# Altium schematic sheet INTERFACE.SchDoc(INTERFACE)
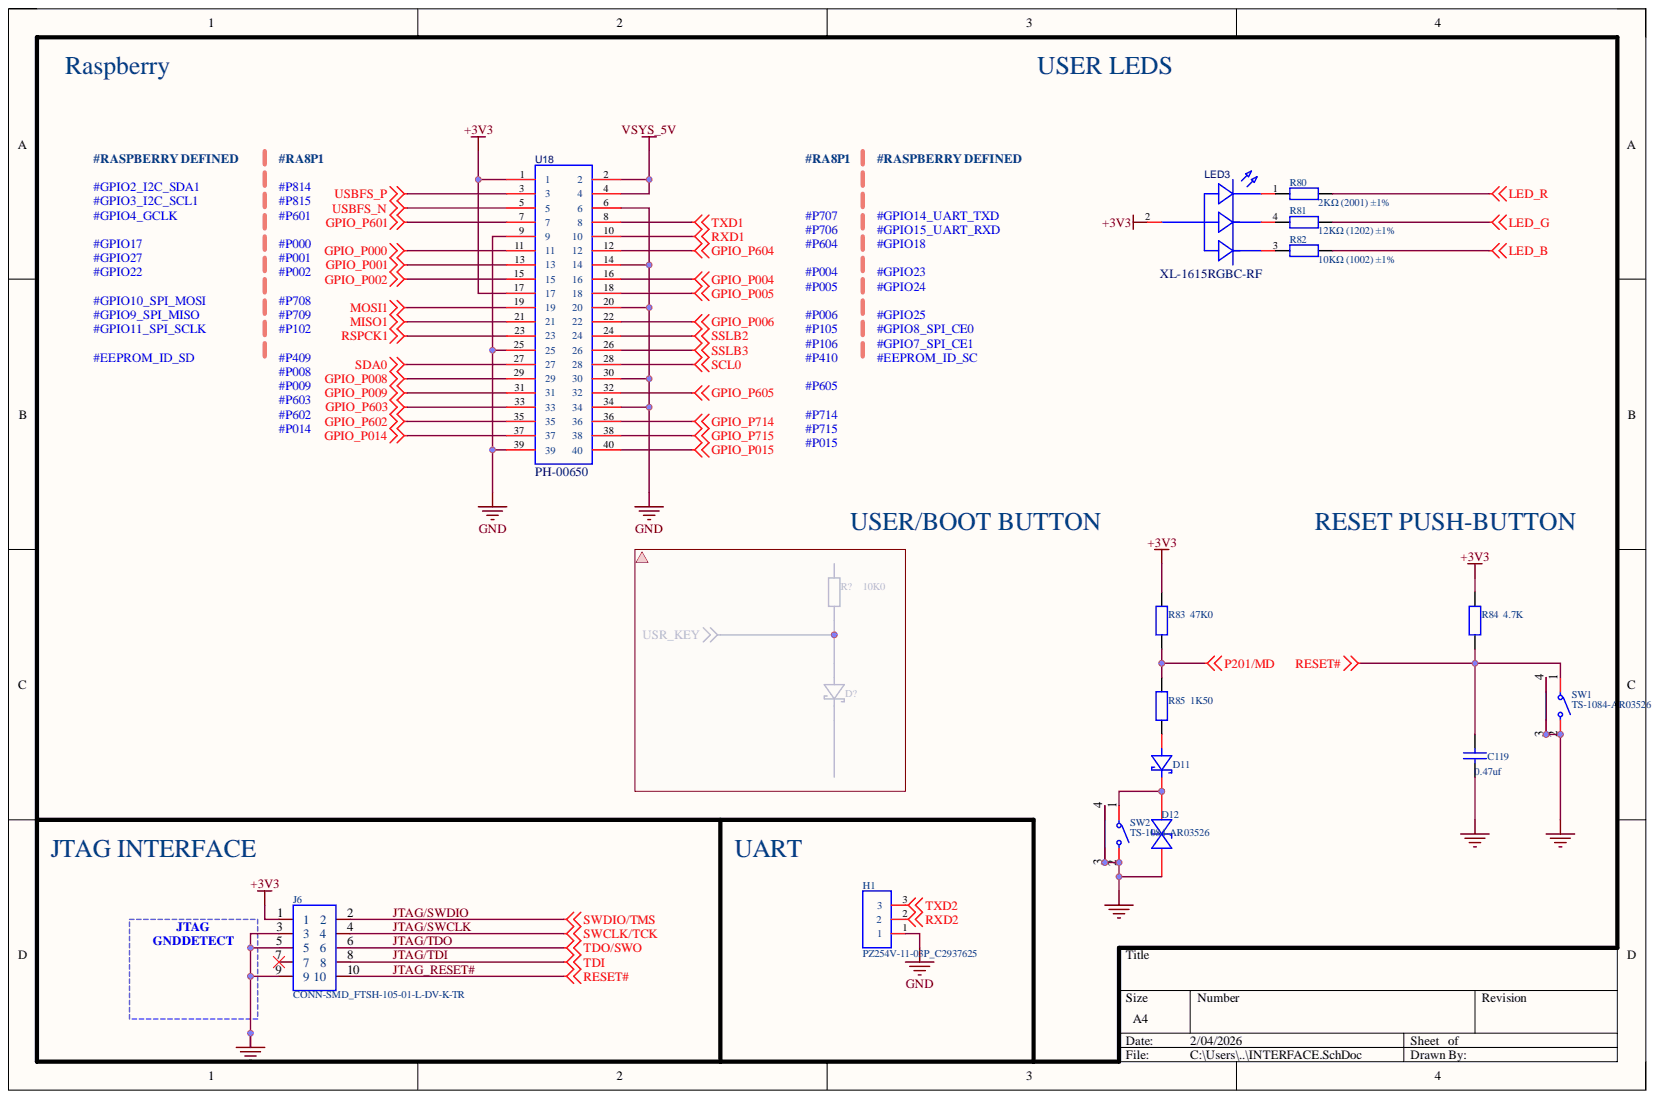
[diagram p0: INTERFACE.SchDoc]

## Parts
C119  0.47uf  [C0402]
D11  1N4148WS  [SOD-323_L1.7-W1.3-LS2.5-RD-1]  (value not drawn)
D12  TPESD0201G05V  [D0201-BI]  (value not drawn)
H1  PZ254V-11-03P_C2937625  [HDR-TH_3P-P2.54-V-M_PZ254V-11-03P]
J6  CONN-SMD_FTSH-105-01-L-DV-K-TR  [CONN-SMD_FTSH-105-01-L-DV-K-TR]
LED3  XL-1615RGBC-RF  [LED-ARRAY-SMD_4P-L1.6-W1.5-BR_XL-1615RGBC-RF]
R80  2KΩ (2001) ±1%  [R0402]
R81  12KΩ (1202) ±1%  [R0402]
R82  10KΩ (1002) ±1%  [R0402]
R83  47K0  [R0402]
R84  4.7K  [R0402]
R85  1K50  [R0402]
SW1  TS-1084-AR03526  [SW-SMD_TS-1084-AR03526]
SW2  TS-1084-AR03526  [SW-SMD_TS-1084-AR03526]
U18  PH-00650  [HDR-SMD_40P-P2.54-V-M-R2-C20-S7.8-EH]

## Nets
+3V3: J6.1 LED3.2 R83.2 R84.2 U18.1 U18.17
GND: C119.1 D12.1 H1.1 J6.3 J6.5 J6.9 SW1.2 SW1.3 SW1.4 SW2.2 SW2.3 SW2.4 U18.6 U18.9 U18.14 U18.20 U18.25 U18.30 U18.34 U18.39
GPIO_P000: U18.11
GPIO_P001: U18.13
GPIO_P002: U18.15
GPIO_P004: U18.16
GPIO_P005: U18.18
GPIO_P006: U18.22
GPIO_P008: U18.29
GPIO_P009: U18.31
GPIO_P014: U18.37
GPIO_P015: U18.40
GPIO_P601: U18.7
GPIO_P602: U18.35
GPIO_P603: U18.33
GPIO_P604: U18.12
GPIO_P605: U18.32
GPIO_P714: U18.36
GPIO_P715: U18.38
LED_B: R82.2
LED_G: R81.2
LED_R: R80.2
MISO1: U18.21
MOSI1: U18.19
P201/MD: R83.1 R85.2
RSPCK1: U18.23
RXD1: U18.10
RXD2: H1.2
SCL0: U18.28
SDA0: U18.27
SSLB2: U18.24
SSLB3: U18.26
TXD1: U18.8
TXD2: H1.3
USBFS_N: U18.5
USBFS_P: U18.3
VSYS_5V: U18.2 U18.4
JTAG/SWCLK: J6.4
JTAG/SWDIO: J6.2
JTAG/TDI: J6.8
JTAG/TDO: J6.6
JTAG_RESET#: C119.2 J6.10 R84.1 SW1.1
NetD11_1: D11.1 D12.2 SW2.1
NetD11_2: D11.2 R85.1
NetLED3_1: LED3.1 R80.1
NetLED3_3: LED3.3 R82.1
NetLED3_4: LED3.4 R81.1

Not connected: J6 7

## Library data of the parts
C119 = CAP-000114-01: Ceramic Chip Capacitor 0402 0.47uF 10V; Manufacturer: Samsung; Manufacturer Part Number 1: CL05A474KP5NNNC; Dialectric: X5R; Notes: ANY EQUIVALENT; Tolerance: 10%; Capacitance: 0.47uF; ClassName: Reset; Component Kind: Standard; Library Name: Capacitors.IntLib; Manufacturer 1: Samsung; Mfg Part Number: CL05A474KP5NNNC; Package: 0402; Supplier 1: Digi-Key; Supplier Part Number 1: 1276-1173-1-ND; Voltage: 10V
D11 = 1N4148WS: 100V,150mA，开关二极管; Manufacturer: 宏嘉诚; Add into BOM: yes; Average Rectified Current (Io): 350mA; Device: SD103AWS_C7420360; Diode Configuration: 独立式; Forward Voltage (Vf@If): 370mV@20mA; Peak forward surge current: 1.5A; Reverse Leakage Current (Ir): 5uA@40V; Reverse Voltage (Vr): 40V; Component Kind: Standard; Convert to PCB: yes; Datasheet: https://atta.szlcsc.com/upload/public/pdf/source/20230828/EB98D42952FEC013AACB2F62A2475546.pdf; JLCPCB Part Class: Extended Part; LCSC Part Name: 电压:40V 电流:350mA; Library Name: ra8p1_v0.2.SCHLIB; Manufacturer Part: 1N4148WS; PCB3D: SOD-323_L1.7-W1.3-LS2.6-RD-1; Supplier: LCSC; Supplier Footprint: SOD-323; Supplier Part: C7420319; Symbol: SD103AWS_C7420360
D12 = TPESD0201G05V: TVS,0201,Vdc=5V，Vc=40V; Manufacturer: TECH PUBLIC(台舟); Add into BOM: yes; Type: ESD; Component Kind: Standard; Convert to PCB: yes; Datasheet: https://atta.szlcsc.com/upload/public/pdf/source/20231208/AB2619EB68EB5FE4BD54B2390A15C9FE.pdf; Device: TPESD0201G05V; JLCPCB Part Class: Extended Part; LCSC Part Name: TPESD0201G05V; Library Name: ra8p1_v0.1.SCHLIB; Manufacturer Part: TPESD0201G05V; PCB3D: D0201_L0.6-W0.3-H0.3; Supplier: LCSC; Supplier Footprint: 0201; Supplier Part: C558457; Symbol: TPESD0201G05V
J6 = HX PZ1.27-2x5P TP: Manufacturer: hanxia(韩下); Add into BOM: yes; Circluar Pins/Square Pins: 方针; Current Rating: 1A; Pin Structure: 2x5P; Pitch: 1.27mm; Color: 黑色; Component Kind: Standard; Contact Material: 黄铜; Contact Plating: 金; Convert to PCB: yes; Datasheet: https://atta.szlcsc.com/upload/public/pdf/source/20240830/6C2540B6182E5928E8E33F1671F88B22.pdf; Device: HX PZ1.27-2x5P TP; Insulation Height: 1mm; JLCPCB Part Class: Extended Part; LCSC Part Name: 2x5P 间距:1.27mm 方针 立贴; Length of End Connection Pin: -; Length of Mating Pin: 4mm; Library Name: RA8P1.SCHLIB; Manufacturer Part: HX PZ1.27-2x5P TP; Mounting Type: 立贴; Number of Pins: 10P; Number of Rows: 双排; Operating Temperature: -40℃~+105℃; PCB3D: HDR-SMD_10P-P1.27-V-M-R2-C5-LS5.5_1; Row Spacing: 1.27mm; Supplementary Features: -; Supplier: LCSC; Supplier Footprint: SMD,P=1.27mm; Supplier Part: C41376037; Symbol: HX PZ1.27-2X5P TP; Voltage Rating (Max): -
R80 = 0402 2KΩ (2001) 1%: 贴片电阻; Component Kind: Standard; ComponentLink1Description: 供应商链接; ComponentLink1URL: http://www.szlcsc.com/product/details_4516.html; Library Name: RES.SchLib; Package: 0402; Supplier: LC; SuppliersPartNumber: C4109
R81 = 0402 12KΩ (1202) 1%: 贴片电阻; Component Kind: Standard; ComponentLink1Description: 供应商链接; ComponentLink1URL: http://www.szlcsc.com/product/details_26495.html; Library Name: RES.SchLib; Package: 0402; Supplier: LC; SuppliersPartNumber: C25752
R82 = 0402 10KΩ (1002) 1%: 贴片电阻，10K; Component Kind: Standard; ComponentLink1Description: 供应商链接; ComponentLink1URL: https://item.szlcsc.com/26487.html?fromZone=l_b__%2522brand%2522&spm=sc.bid.xh1.zy.n&lcsc_vid=FANcVFBQFQMNX1cFFAdZVVZXEgdeAgdWFlQNUVAHT1ExVlNSRFhXVlJQQFlYVzsOAxUeFF5JWA0HFBBIBBsCBBcFWQIBCksEBQAAA0tfTk8GEwkK; Library Name: RA8P1.SCHLIB; Package: 0402; Supplier: LC; SuppliersPartNumber: C25744
R83 = RES-000173-01: Chip Resistor Thick Film 0402 47K0 1% 1/10W; Manufacturer: Panasonic; Manufacturer Part Number 1: ERJ-2RKF4702X; Notes: ANY EQUIVALENT; ClassName: Boot-Mode; Component Kind: Standard; Library Name: Resistors.IntLib; Manufacturer 1: Panasonic; Mfg Part Number: ERJ-2RKF4702X; Package: 0402; Power: 1/10W; Resistance: 47K0; Supplier 1: Digi-Key; Supplier Part Number 1: P47.0KLCT-ND; Tolerance: 1%
R84 = RES-100119-01: Chip Resistor Thick Film 0402 4.7K ohm 1% 1/16W; Legacy Part Number: 150-00000094; Manufacturer: Yageo; Manufacturer Part Number 1: RC0402FR-074K7L; Notes: ANY EQUIVALENT; Resistance: 4K7; ClassName: Reset; Component Kind: Standard; Library Name: Resistors.IntLib; Manufacturer 1: Yageo; Mfg: Yageo; Mfg P/N: RC0402FR-074K7L; Mfg Part Number: RC0402FR-074K7L; Package: 0402; PN: RC0402FR-074K7L; Power: 1/16W; Supplier 1: Future Electronics; Supplier 2: Digi-Key; Supplier 3: Mouser; Supplier 4: Farnell; Supplier Part Number 1: 3795218; Supplier Part Number 2: 311-4.7KLRCT-ND; Supplier Part Number 3: 603-RC0402FR-074K7L; Supplier Part Number 4: 9239316; Tolerance: 1%
R85 = RES-000140-01: Chip Resistor Thick Film 0402 1K50 0.5% 1/16W; Manufacturer: Panasonic; Manufacturer Part Number 1: ERA-2AED152X; Notes: ANY EQUIVALENT; ClassName: Boot-Mode; Component Kind: Standard; Library Name: Resistors.IntLib; Manufacturer 1: Panasonic; Mfg Part Number: ERA-2AED152X; Package: 0402; Power: 1/16W; Resistance: 1K50; Supplier 1: Digi-Key; Supplier Part Number 1: P1.5KDECT-ND; Tolerance: 0.5%
SW1 SW2 = TS-1084-AR03526: 按键侧贴，2.6N; Manufacturer: XUNPU(讯普); Actuator Style: 圆形按钮; Actuator/Cap Color: 白色; Add into BOM: yes; Circuit: 单刀单掷; Contact Current: 50mA; Insulation Resistance: 100MΩ; Mechanical Life: 10万次; Operating Force: 260gf; Pin Style: SMD接片; Switch Height: 3.5mm; Switch Length: 4.5mm; Switch Width: 3.4mm; Voltage Rating (DC): 12V; Component Kind: Standard; Convert to PCB: yes; Datasheet: https://atta.szlcsc.com/upload/public/pdf/source/20200810/C720495_E832ACC0F01765863927299F269536F0.pdf; Device: TS-1084-AR03526; JLCPCB Part Class: Extended Part; LCSC Part Name: 4.5*3.4*3.5mm 卧贴 轻触开关; Library Name: ra8p1_v0.2.SCHLIB; Manufacturer Part: TS-1084-AR03526; Mounting Style: 卧贴; Operating Temperature: -30℃~+80℃; PCB3D: SW-SMD_TS-1084-AR03526; Supplier: LCSC; Supplier Footprint: SMD; Supplier Part: C720495; Symbol: TS-1084-AR03526
U18 = PH-00650: 40PIN,立贴; Component Kind: Standard; Library Name: RA8P1.SCHLIB; Symbol: PH-00650
H1 = PZ254V-11-03P_C2937625: Component Kind: Standard; Library Name: RA8P1.SCHLIB; Symbol: PZ254V-11-03P_C2937625
LED3 = XL-1615RGBC-RF: Component Kind: Standard; Library Name: RA8P1.SCHLIB; Symbol: XL-1615RGBC-RF
